# Altium schematic sheet 3_LEDs_rB1.SchDoc
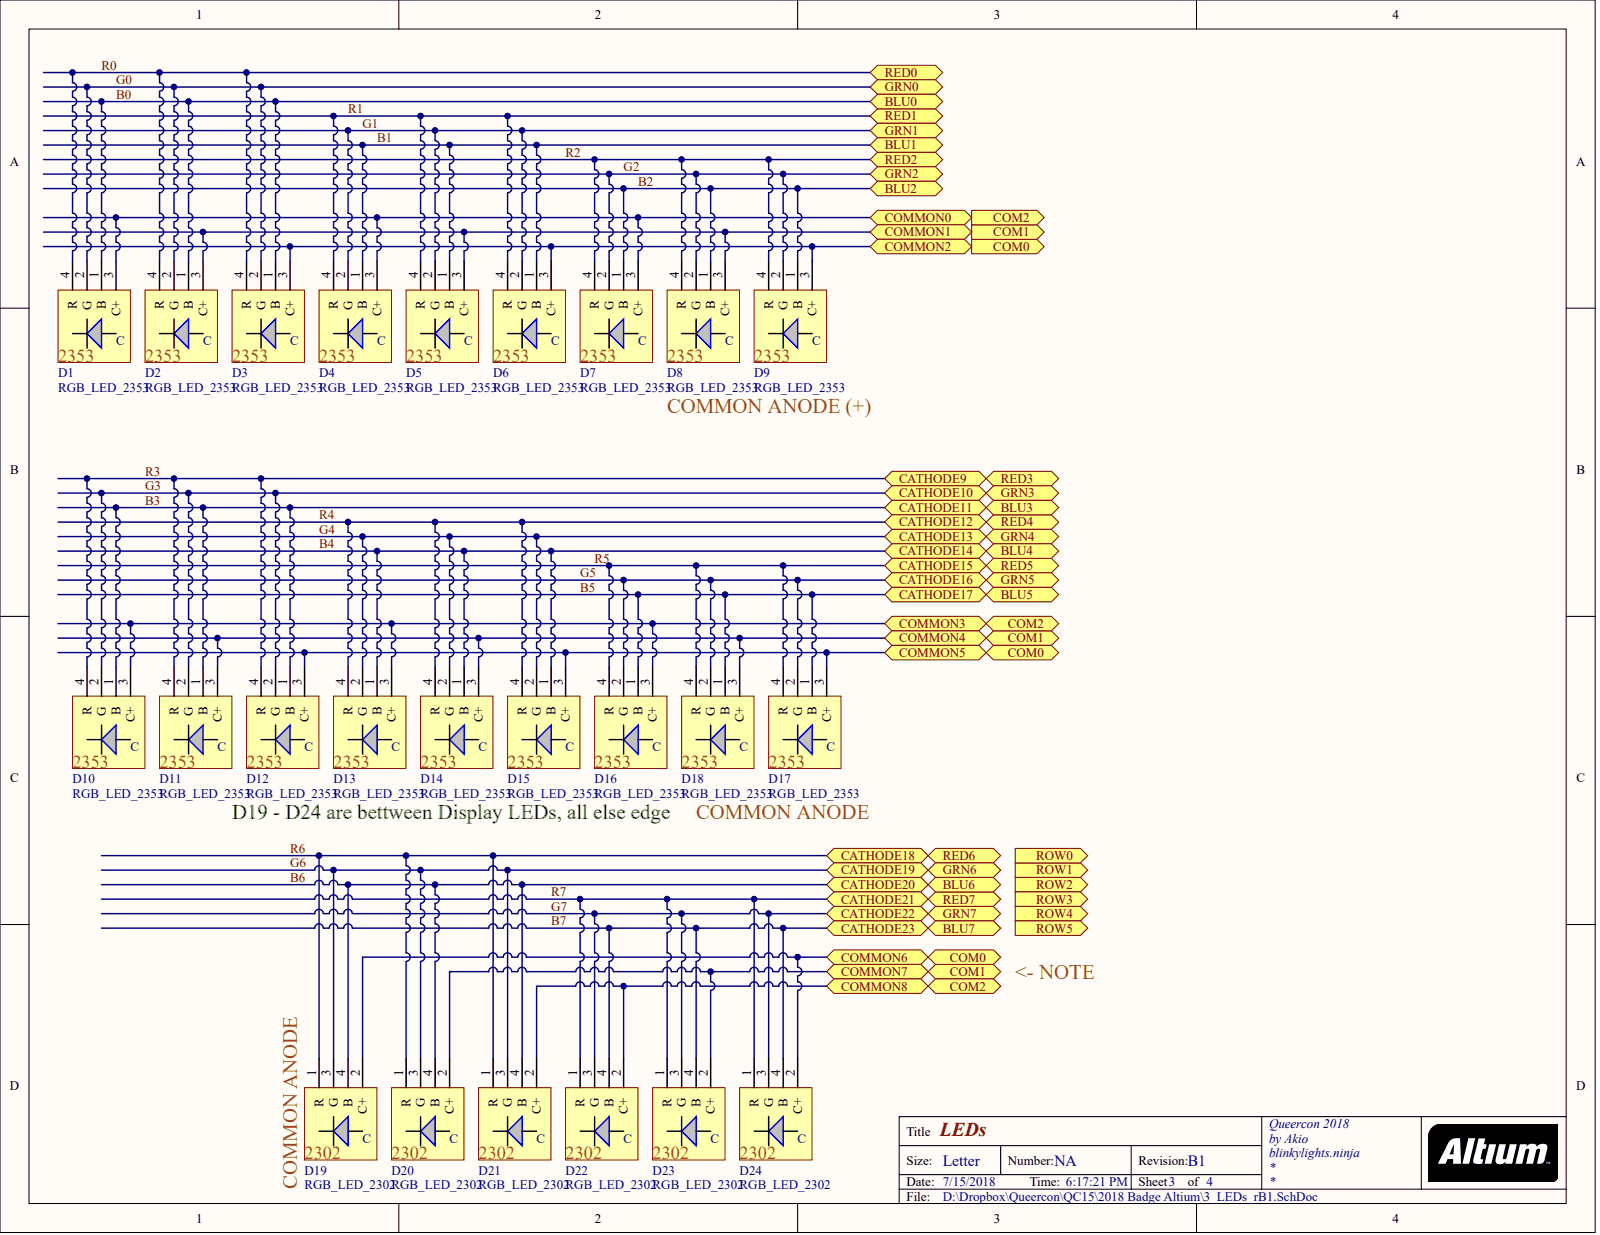
[diagram p0: 3_LEDs_rB1.SchDoc]

## Parts
D1  RGB_LED_2353
D2  RGB_LED_2353
D3  RGB_LED_2353
D4  RGB_LED_2353
D5  RGB_LED_2353
D6  RGB_LED_2353
D7  RGB_LED_2353
D8  RGB_LED_2353
D9  RGB_LED_2353
D10  RGB_LED_2353
D11  RGB_LED_2353
D12  RGB_LED_2353
D13  RGB_LED_2353
D14  RGB_LED_2353
D15  RGB_LED_2353
D16  RGB_LED_2353
D17  RGB_LED_2353
D18  RGB_LED_2353
D19  RGB_LED_2302
D20  RGB_LED_2302
D21  RGB_LED_2302
D22  RGB_LED_2302
D23  RGB_LED_2302
D24  RGB_LED_2302

## Ports
BLU0, BLU1, BLU2, BLU3, BLU4, BLU5, BLU6, BLU7, CATHODE9, CATHODE10, CATHODE11, CATHODE12, CATHODE13, CATHODE14, CATHODE15, CATHODE16, CATHODE17, CATHODE18, CATHODE19, CATHODE20, CATHODE21, CATHODE22, CATHODE23, COM0, COM1, COM2, COMMON0, COMMON1, COMMON2, COMMON3, COMMON4, COMMON5, COMMON6, COMMON7, COMMON8, GRN0, GRN1, GRN2, GRN3, GRN4, GRN5, GRN6, GRN7, RED0, RED1, RED2, RED3, RED4, RED5, RED6, RED7, ROW0, ROW1, ROW2, ROW3, ROW4, ROW5

## Nets
B0: D1.1(B) D2.1(B) D3.1(B) ; port BLU0
B1: D4.1(B) D5.1(B) D6.1(B) ; port BLU1
B2: D7.1(B) D8.1(B) D9.1(B) ; port BLU2
B3: D10.1(B) D11.1(B) D12.1(B) ; port BLU3, port CATHODE11
B4: D13.1(B) D14.1(B) D15.1(B) ; port BLU4, port CATHODE14
B5: D16.1(B) D17.1(B) D18.1(B) ; port BLU5, port CATHODE17
B6: D19.4(B) D20.4(B) D21.4(B) ; port BLU6, port CATHODE20
B7: D22.4(B) D23.4(B) D24.4(B) ; port BLU7, port CATHODE23
COM0: D3.3(C+) D6.3(C+) D9.3(C+) D12.3(C+) D15.3(C+) D17.3(C+) D19.2(C+) D24.2(C+) ; port COM0, port COMMON2, port COMMON5, port COMMON6
COM1: D2.3(C+) D5.3(C+) D8.3(C+) D11.3(C+) D14.3(C+) D18.3(C+) D20.2(C+) D23.2(C+) ; port COM1, port COMMON1, port COMMON4, port COMMON7
COM2: D1.3(C+) D4.3(C+) D7.3(C+) D10.3(C+) D13.3(C+) D16.3(C+) D21.2(C+) D22.2(C+) ; port COM2, port COMMON0, port COMMON3, port COMMON8
G0: D1.2(G) D2.2(G) D3.2(G) ; port GRN0
G1: D4.2(G) D5.2(G) D6.2(G) ; port GRN1
G2: D7.2(G) D8.2(G) D9.2(G) ; port GRN2
G3: D10.2(G) D11.2(G) D12.2(G) ; port CATHODE10, port GRN3
G4: D13.2(G) D14.2(G) D15.2(G) ; port CATHODE13, port GRN4
G5: D16.2(G) D17.2(G) D18.2(G) ; port CATHODE16, port GRN5
G6: D19.3(G) D20.3(G) D21.3(G) ; port CATHODE19, port GRN6
G7: D22.3(G) D23.3(G) D24.3(G) ; port CATHODE22, port GRN7
R0: D1.4(R) D2.4(R) D3.4(R) ; port RED0
R1: D4.4(R) D5.4(R) D6.4(R) ; port RED1
R2: D7.4(R) D8.4(R) D9.4(R) ; port RED2
R3: D10.4(R) D11.4(R) D12.4(R) ; port CATHODE9, port RED3
R4: D13.4(R) D14.4(R) D15.4(R) ; port CATHODE12, port RED4
R5: D16.4(R) D17.4(R) D18.4(R) ; port CATHODE15, port RED5
R6: D19.1(R) D20.1(R) D21.1(R) ; port CATHODE18, port RED6
R7: D22.1(R) D23.1(R) D24.1(R) ; port CATHODE21, port RED7
N00017: ; port ROW0
N00018: ; port ROW5
N00019: ; port ROW4
N00020: ; port ROW3
N00021: ; port ROW2
N00022: ; port ROW1
